# Altium schematic sheet BTT_M157_MCU_V0.3.SchDoc(BTT_M157_MCU_V0.3)
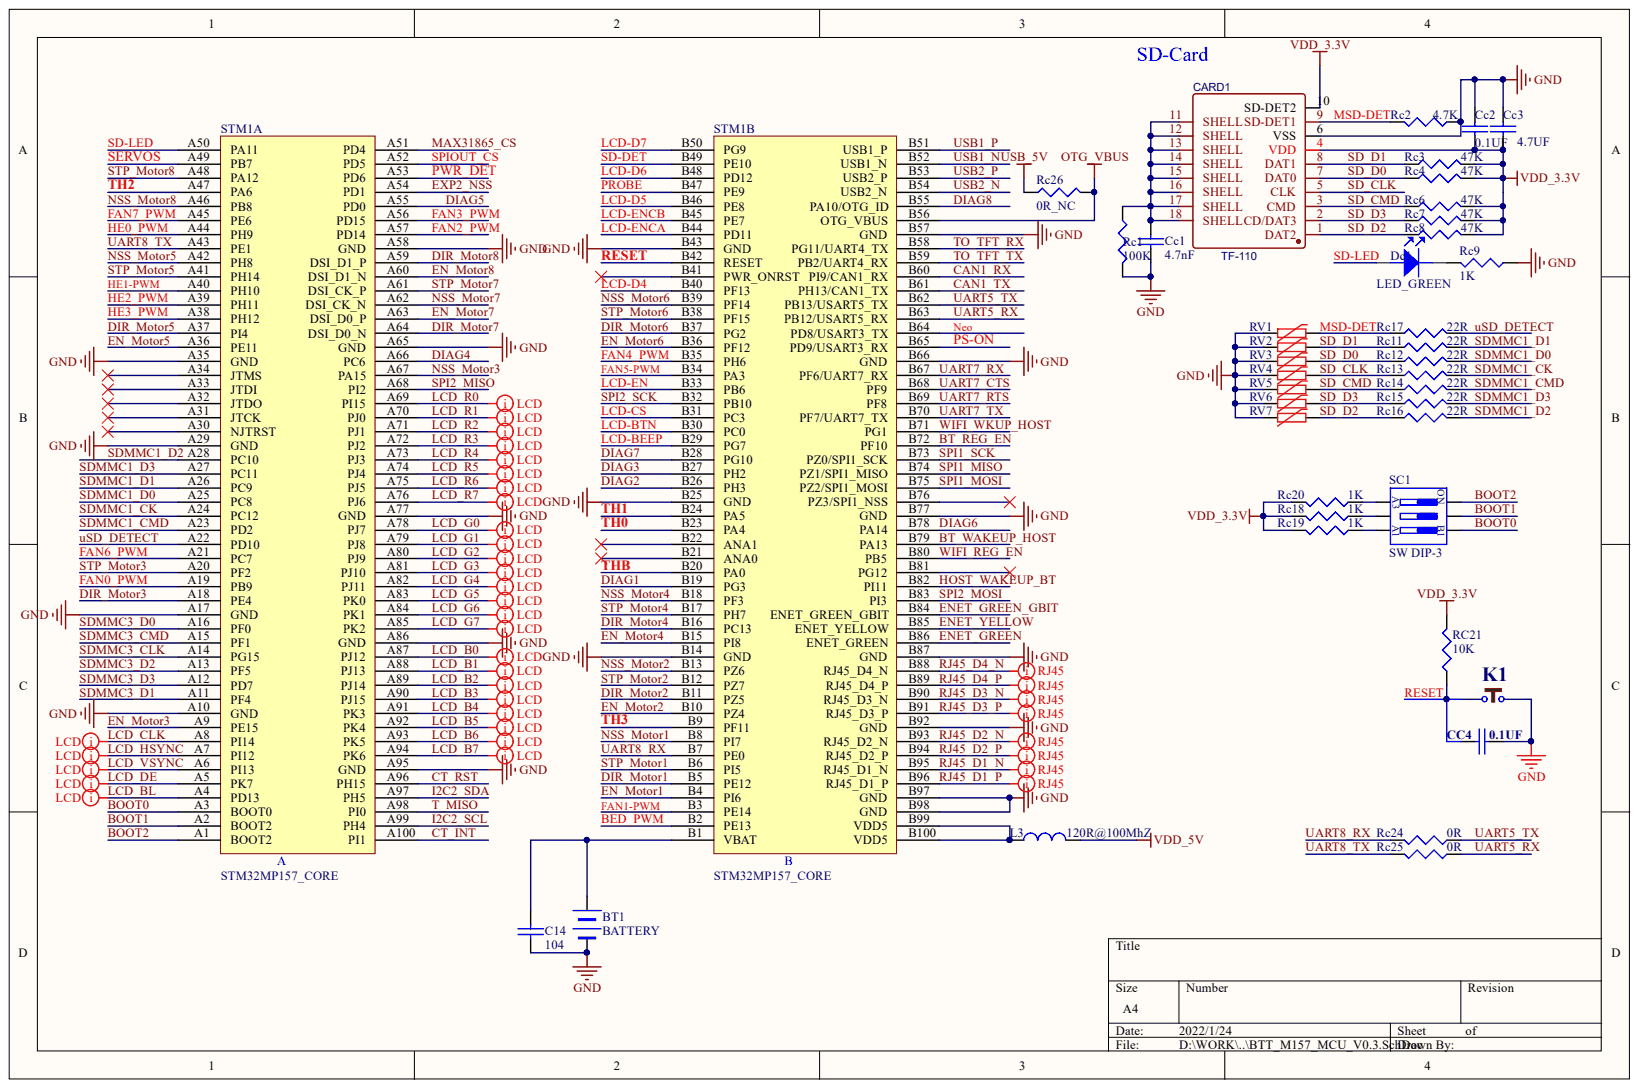
[diagram p0: BTT_M157_MCU_V0.3.SchDoc]

## Parts
BT1  BATTERY  [cr1220]
C14  104  [0603c]
CARD1  TF-110  [TF-SMD_TF-110]
Cc1  4.7nF  [0603C]
Cc2  0.1UF  [0603C]
Cc3  4.7UF  [0603C]
CC4  0.1UF  [0603C]
Dc1  LED_GREEN  [LED-0603-green]
K1  RESET  [KEY-SMD_4P-L4.2-W3.2-P2.20-LS5.1-TL]
L3  120R@100MhZ  [0805L]
Rc1  100K  [0603R]
Rc2  4.7K  [0603R]
Rc3  47K  [0603R]
Rc4  47K  [0603R]
Rc6  47K  [0603R]
Rc7  47K  [0603R]
Rc8  47K  [0603R]
Rc9  1K  [0603R]
Rc11  22R  [0603R]
Rc12  22R  [0603R]
Rc13  22R  [0603R]
Rc14  22R  [0603R]
Rc15  22R  [0603R]
Rc16  22R  [0603R]
Rc17  22R  [0603R]
Rc18  1K  [0603R]
Rc19  1K  [0603R]
Rc20  1K  [0603R]
RC21  10K  [0603R]
Rc24  0R  [0603R]
Rc25  0R  [0603R]
Rc26  0R_NC  [0603R]
RV1  CG0603MLC-05E  [0603L]  (value not drawn)
RV2  CG0603MLC-05E  [0603L]  (value not drawn)
RV3  CG0603MLC-05E  [0603L]  (value not drawn)
RV4  CG0603MLC-05E  [0603L]  (value not drawn)
RV5  CG0603MLC-05E  [0603L]  (value not drawn)
RV6  CG0603MLC-05E  [0603L]  (value not drawn)
RV7  CG0603MLC-05E  [0603L]  (value not drawn)
SC1  SW DIP-3  [SW DIP-3P_G]
STM1  STM32MP157_CORE  [STM32MP157_CORE_V1.0]  (parts A,B)

## Nets
GND: BT1.2 C14.2 CARD1.6(VSS) Cc1.1 Cc2.1 Cc3.1 CC4.1 K1.2 Rc1.2 Rc2.1 Rc9.1 RV1.1 RV2.1 RV3.1 RV4.1 RV5.1 RV6.1 RV7.1 STM1.A10(GND) STM1.A17(GND) STM1.A29(GND) STM1.A35(GND) STM1.A58(GND) STM1.A65(GND) STM1.A77(GND) STM1.A86(GND) STM1.A95(GND) STM1.B14(GND) STM1.B25(GND) STM1.B43(GND) STM1.B57(GND) STM1.B66(GND) STM1.B77(GND) STM1.B87(GND) STM1.B92(GND) STM1.B97(GND) STM1.B98(GND)
OTG_VBUS: Rc26.2 STM1.B56(OTG_VBUS)
USB_5V: Rc26.1
VDD_3.3V: CARD1.4(VDD) CARD1.10(SD-DET2) Cc2.2 Cc3.2 Rc3.2 Rc4.2 Rc6.2 Rc7.2 Rc8.2 Rc18.2 Rc19.2 Rc20.2 RC21.2
VDD_5V: L3.2
BED_PWM: STM1.B2(PE13)
BOOT0: SC1.B1 STM1.A3(BOOT0)
BOOT1: SC1.B2 STM1.A2(BOOT2)
BOOT2: SC1.B3(ON) STM1.A1(BOOT2)
BT_REG_EN: STM1.B72(PF10)
BT_WAKEUP_HOST: STM1.B79(PA13)
CAN1_RX: STM1.B60(PI9/CAN1_RX)
CAN1_TX: STM1.B61(PH13/CAN1_TX)
CT_INT: STM1.A100(PI1)
CT_RST: STM1.A96(PH15)
DIAG1: STM1.B19(PG3)
DIAG2: STM1.B26(PH3)
DIAG3: STM1.B27(PH2)
DIAG4: STM1.A66(PC6)
DIAG5: STM1.A55(PD0)
DIAG6: STM1.B78(PA14)
DIAG7: STM1.B28(PG10)
DIAG8: STM1.B55(PA10/OTG_ID)
DIR_MOTOR1: STM1.B5(PE12)
DIR_MOTOR2: STM1.B11(PZ5)
DIR_MOTOR3: STM1.A18(PE4)
DIR_MOTOR4: STM1.B16(PC13)
DIR_MOTOR5: STM1.A37(PI4)
DIR_MOTOR6: STM1.B37(PG2)
DIR_MOTOR7: STM1.A64(DSI_D0_N)
DIR_MOTOR8: STM1.A59(DSI_D1_P)
EN_MOTOR1: STM1.B4(PI6)
EN_MOTOR2: STM1.B10(PZ4)
EN_MOTOR3: STM1.A9(PE15)
EN_MOTOR4: STM1.B15(PI8)
EN_MOTOR5: STM1.A36(PE11)
EN_MOTOR6: STM1.B36(PF12)
EN_MOTOR7: STM1.A63(DSI_D0_P)
EN_MOTOR8: STM1.A60(DSI_D1_N)
ENET_GREEN: STM1.B86(ENET_GREEN)
ENET_GREEN_GBIT: STM1.B84(ENET_GREEN_GBIT)
ENET_YELLOW: STM1.B85(ENET_YELLOW)
EXP2_NSS: STM1.A54(PD1)
FAN0_PWM: STM1.A19(PB9)
FAN1-PWM: STM1.B3(PE14)
FAN2_PWM: STM1.A57(PD14)
FAN3_PWM: STM1.A56(PD15)
FAN4_PWM: STM1.B35(PH6)
FAN5-PWM: STM1.B34(PA3)
FAN6_PWM: STM1.A21(PC7)
FAN7_PWM: STM1.A45(PE6)
HE0_PWM: STM1.A44(PH9)
HE1-PWM: STM1.A40(PH10)
HE2_PWM: STM1.A39(PH11)
HE3_PWM: STM1.A38(PH12)
HOST_WAKEUP_BT: STM1.B82(PI11)
I2C2_SCL: STM1.A99(PH4)
I2C2_SDA: STM1.A97(PH5)
LCD-BEEP: STM1.B29(PG7)
LCD-BTN: STM1.B30(PC0)
LCD-CS: STM1.B31(PC3)
LCD-D4: STM1.B40(PF13)
LCD-D5: STM1.B46(PE8)
LCD-D6: STM1.B48(PD12)
LCD-D7: STM1.B50(PG9)
LCD-EN: STM1.B33(PB6)
LCD-ENCA: STM1.B44(PD11)
LCD-ENCB: STM1.B45(PE7)
LCD_B0: STM1.A87(PJ12)
LCD_B1: STM1.A88(PJ13)
LCD_B2: STM1.A89(PJ14)
LCD_B3: STM1.A90(PJ15)
LCD_B4: STM1.A91(PK3)
LCD_B5: STM1.A92(PK4)
LCD_B6: STM1.A93(PK5)
LCD_B7: STM1.A94(PK6)
LCD_BL: STM1.A4(PD13)
LCD_CLK: STM1.A8(PI14)
LCD_DE: STM1.A5(PK7)
LCD_G0: STM1.A78(PJ7)
LCD_G1: STM1.A79(PJ8)
LCD_G2: STM1.A80(PJ9)
LCD_G3: STM1.A81(PJ10)
LCD_G4: STM1.A82(PJ11)
LCD_G5: STM1.A83(PK0)
LCD_G6: STM1.A84(PK1)
LCD_G7: STM1.A85(PK2)
LCD_HSYNC: STM1.A7(PI12)
LCD_R0: STM1.A69(PI15)
LCD_R1: STM1.A70(PJ0)
LCD_R2: STM1.A71(PJ1)
LCD_R3: STM1.A72(PJ2)
LCD_R4: STM1.A73(PJ3)
LCD_R5: STM1.A74(PJ4)
LCD_R6: STM1.A75(PJ5)
LCD_R7: STM1.A76(PJ6)
LCD_VSYNC: STM1.A6(PI13)
MAX31865_CS: STM1.A51(PD4)
MSD-DET: CARD1.9(SD-DET1) Rc2.2 Rc17.1 RV1.2
NEO: STM1.B64(PD8/USART3_TX)
NSS_MOTOR1: STM1.B8(PI7)
NSS_MOTOR2: STM1.B13(PZ6)
NSS_MOTOR3: STM1.A67(PA15)
NSS_MOTOR4: STM1.B18(PF3)
NSS_MOTOR5: STM1.A42(PH8)
NSS_MOTOR6: STM1.B39(PF14)
NSS_MOTOR7: STM1.A62(DSI_CK_N)
NSS_MOTOR8: STM1.A46(PB8)
PROBE: STM1.B47(PE9)
PS-ON: STM1.B65(PD9/USART3_RX)
PWR_DET: STM1.A53(PD6)
RESET: CC4.2 K1.1 RC21.1 STM1.B42(RESET)
RJ45_D1_N: STM1.B95(RJ45_D1_N)
RJ45_D1_P: STM1.B96(RJ45_D1_P)
RJ45_D2_N: STM1.B93(RJ45_D2_N)
RJ45_D2_P: STM1.B94(RJ45_D2_P)
RJ45_D3_N: STM1.B90(RJ45_D3_N)
RJ45_D3_P: STM1.B91(RJ45_D3_P)
RJ45_D4_N: STM1.B88(RJ45_D4_N)
RJ45_D4_P: STM1.B89(RJ45_D4_P)
SD-DET: STM1.B49(PE10)
SD-LED: Dc1.A STM1.A50(PA11)
SD_CLK: CARD1.5(CLK) Rc13.1 RV4.2
SD_CMD: CARD1.3(CMD) Rc6.1 Rc14.1 RV5.2
SD_D0: CARD1.7(DAT0) Rc4.1 Rc12.1 RV3.2
SD_D1: CARD1.8(DAT1) Rc3.1 Rc11.1 RV2.2
SD_D2: CARD1.1(DAT2) Rc8.1 Rc16.1 RV7.2
SD_D3: CARD1.2(CD/DAT3) Rc7.1 Rc15.1 RV6.2
SDMMC1_CK: Rc13.2 STM1.A24(PC12)
SDMMC1_CMD: Rc14.2 STM1.A23(PD2)
SDMMC1_D0: Rc12.2 STM1.A25(PC8)
SDMMC1_D1: Rc11.2 STM1.A26(PC9)
SDMMC1_D2: Rc16.2 STM1.A28(PC10)
SDMMC1_D3: Rc15.2 STM1.A27(PC11)
SDMMC3_CLK: STM1.A14(PG15)
SDMMC3_CMD: STM1.A15(PF1)
SDMMC3_D0: STM1.A16(PF0)
SDMMC3_D1: STM1.A11(PF4)
SDMMC3_D2: STM1.A13(PF5)
SDMMC3_D3: STM1.A12(PD7)
SERVOS: STM1.A49(PB7)
SPI1_MISO: STM1.B74(PZ1/SPI1_MISO)
SPI1_MOSI: STM1.B75(PZ2/SPI1_MOSI)
SPI1_SCK: STM1.B73(PZ0/SPI1_SCK)
SPI2_MISO: STM1.A68(PI2)
SPI2_MOSI: STM1.B83(PI3)
SPI2_SCK: STM1.B32(PB10)
SPIOUT_CS: STM1.A52(PD5)
STP_MOTOR1: STM1.B6(PI5)
STP_MOTOR2: STM1.B12(PZ7)
STP_MOTOR3: STM1.A20(PF2)
STP_MOTOR4: STM1.B17(PH7)
STP_MOTOR5: STM1.A41(PH14)
STP_MOTOR6: STM1.B38(PF15)
STP_MOTOR7: STM1.A61(DSI_CK_P)
STP_MOTOR8: STM1.A48(PA12)
T_MISO: STM1.A98(PI0)
TH0: STM1.B23(PA4)
TH1: STM1.B24(PA5)
TH2: STM1.A47(PA6)
TH3: STM1.B9(PF11)
THB: STM1.B20(PA0)
TO_TFT_RX: STM1.B58(PG11/UART4_TX)
TO_TFT_TX: STM1.B59(PB2/UART4_RX)
UART5_RX: Rc25.1 STM1.B63(PB12/USART5_RX)
UART5_TX: Rc24.1 STM1.B62(PB13/USART5_TX)
UART7_CTS: STM1.B68(PF9)
UART7_RTS: STM1.B69(PF8)
UART7_RX: STM1.B67(PF6/UART7_RX)
UART7_TX: STM1.B70(PF7/UART7_TX)
UART8_RX: Rc24.2 STM1.B7(PE0)
UART8_TX: Rc25.2 STM1.A43(PE1)
USB1_N: STM1.B52(USB1_N)
USB1_P: STM1.B51(USB1_P)
USB2_N: STM1.B54(USB2_N)
USB2_P: STM1.B53(USB2_P)
USD_DETECT: Rc17.2 STM1.A22(PD10)
WIFI_REG_EN: STM1.B80(PB5)
WIFI_WKUP_HOST: STM1.B71(PG1)
NetBT1_1: BT1.1 C14.1 STM1.B1(VBAT)
NetCARD1_11: CARD1.11(SHELL) CARD1.12(SHELL) CARD1.13(SHELL) CARD1.14(SHELL) CARD1.15(SHELL) CARD1.16(SHELL) CARD1.17(SHELL) CARD1.18(SHELL) Cc1.2 Rc1.1
NetDc1_K: Dc1.K Rc9.2
NetL3_1: L3.1 STM1.B99(VDD5) STM1.B100(VDD5)
NetRc18_1: Rc18.1 SC1.A2
NetRc19_1: Rc19.1 SC1.A1
NetRc20_1: Rc20.1 SC1.A3

Not connected: STM1 A30(NJTRST) A31(JTCK) A32(JTDO) A33(JTDI) A34(JTMS) B21(ANA0) B22(ANA1) B41(PWR_ONRST) B76(PZ3/SPI1_NSS) B81(PG12)

## Library data of the parts
C14 = CAP: Capacitor; Component Kind: Standard; Library Name: My Useful Discrete Devices.SchLib
CARD1 = TF-110: BOM_Manufacturer: XUNPU; Contributor: LCSC; BOM_JLCPCB Part Class: Extended Part; BOM_Manufacturer Part: TF-110; BOM_Supplier: LCSC; BOM_Supplier Part: C266613; Component Kind: Standard; spicePre: C; spiceSymbolName: TF-110; Library Name: 常用库.SCHLIB
Cc1-Cc3 CC4 = CAP: Component Kind: Standard; Library Name: liroqi.SchLib
Dc1 = LED: Component Kind: Standard; Library Name: My Useful Discrete Devices.SchLib
K1 = K3: Component Kind: Standard; Library Name: liroqi.SchLib
L3 = INDUCTOR: Component Kind: Standard; Library Name: My Useful Discrete Devices.SchLib
Rc1-Rc4 Rc6-Rc9 Rc11-Rc20 RC21 Rc24-Rc26 = RES1: Component Kind: Standard
  Rc1-Rc4 Rc6-Rc9 Rc18-Rc20 Rc24-Rc26: Library Name: 常用库.SCHLIB
  Rc11-Rc17 RC21: Library Name: My Useful Discrete Devices.SchLib
RV1-RV7 = CG0603MLC-05E: VAR 0603 5V; Manufacturer: YAGEO; EE_SPEC: 5VDC; Tolerance: NA; Component Kind: Standard; DISTRIBUTOR: Digi-Key; DISTRIBUTOR #: CG0603MLC-05ETR-ND; Library Name: 3Delight.SCHLIB; MANUFACTURER #: CG0603MLC-05E; PART: 5VDC; Part Description: VARISTOR 0603; Signal Integrity: Model Name; Simulation: Model Name; VALUE: 5V
SC1 = SW DIP-3: DIP Switch; Component Kind: Standard; Library Name: My Useful Discrete Devices.SchLib
STM1 = STM32MP157核心板: Component Kind: Standard; Description: STM32MP157核心板; Library Name: My Useful IC.SchLib
BT1 = BATTERY: Component Kind: Standard; Description: Battery; Library Name: My Useful Discrete Devices.SchLib
